# Altium schematic sheet CDU_Logic.SchDoc(CDU_Logic)
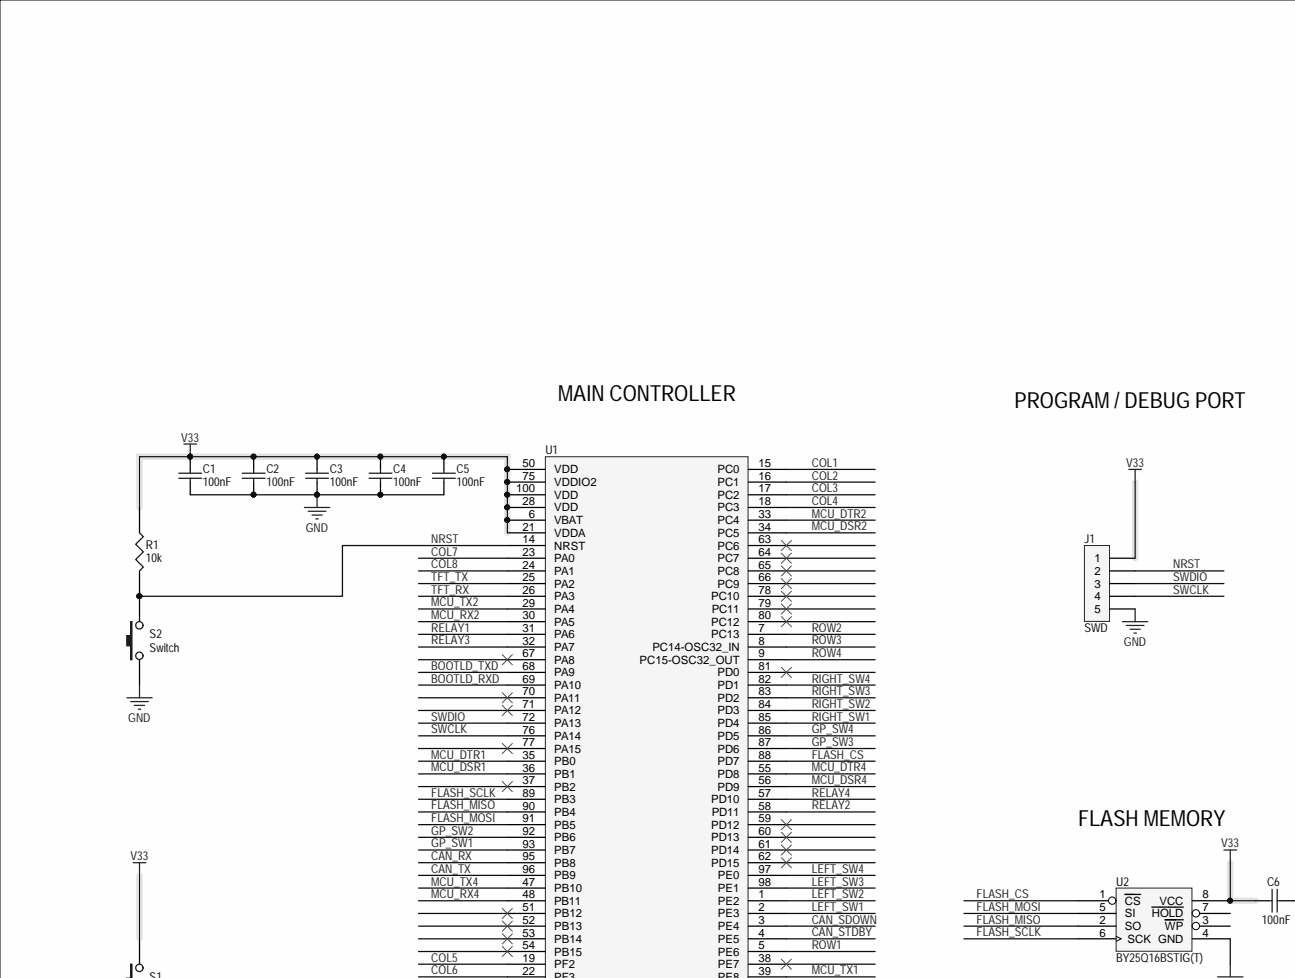
[diagram p0: CDU_Logic.SchDoc, top left]
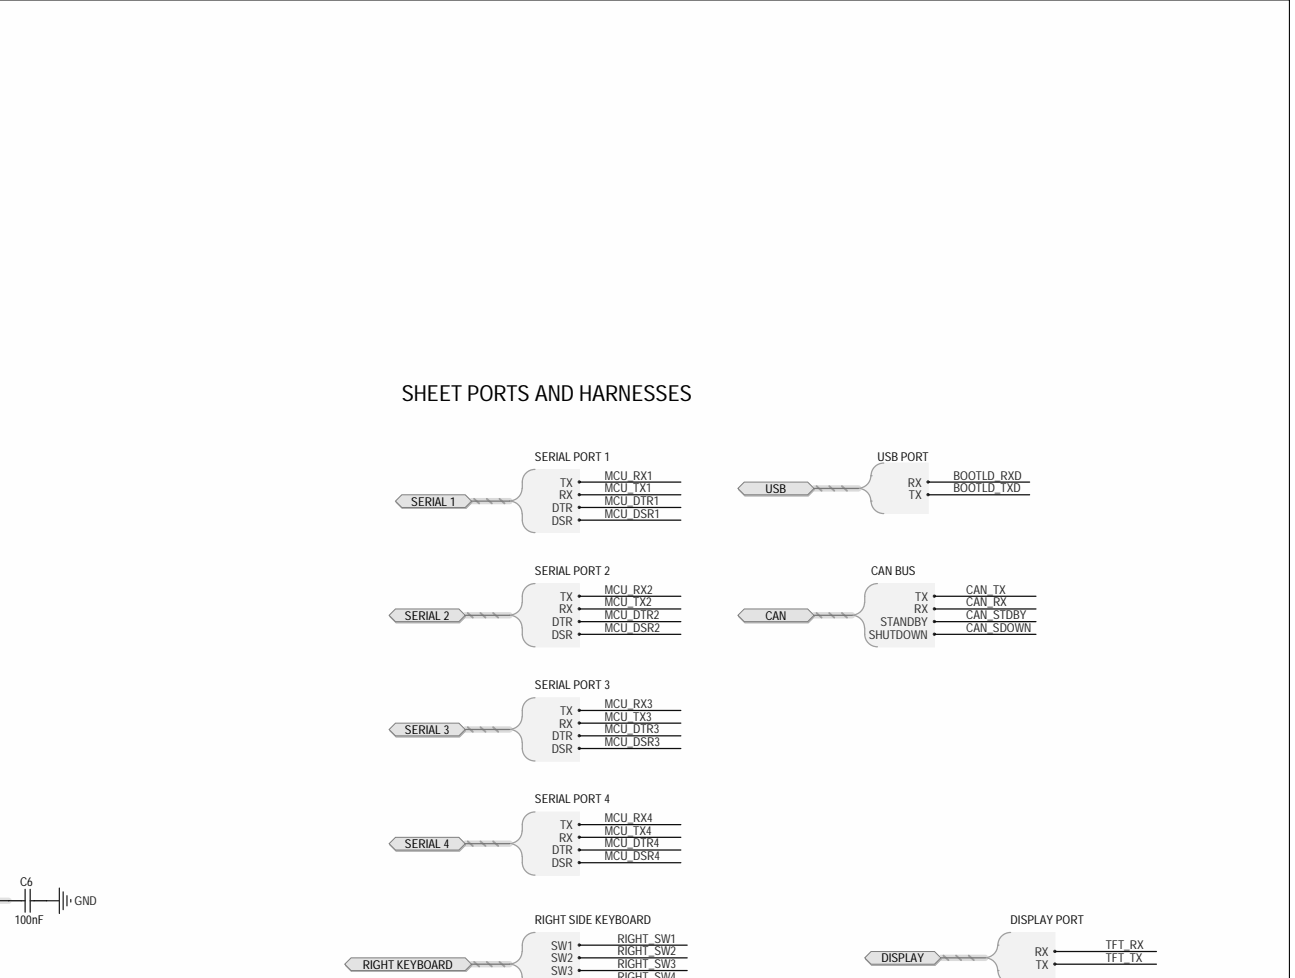
[diagram p1: CDU_Logic.SchDoc, top right]
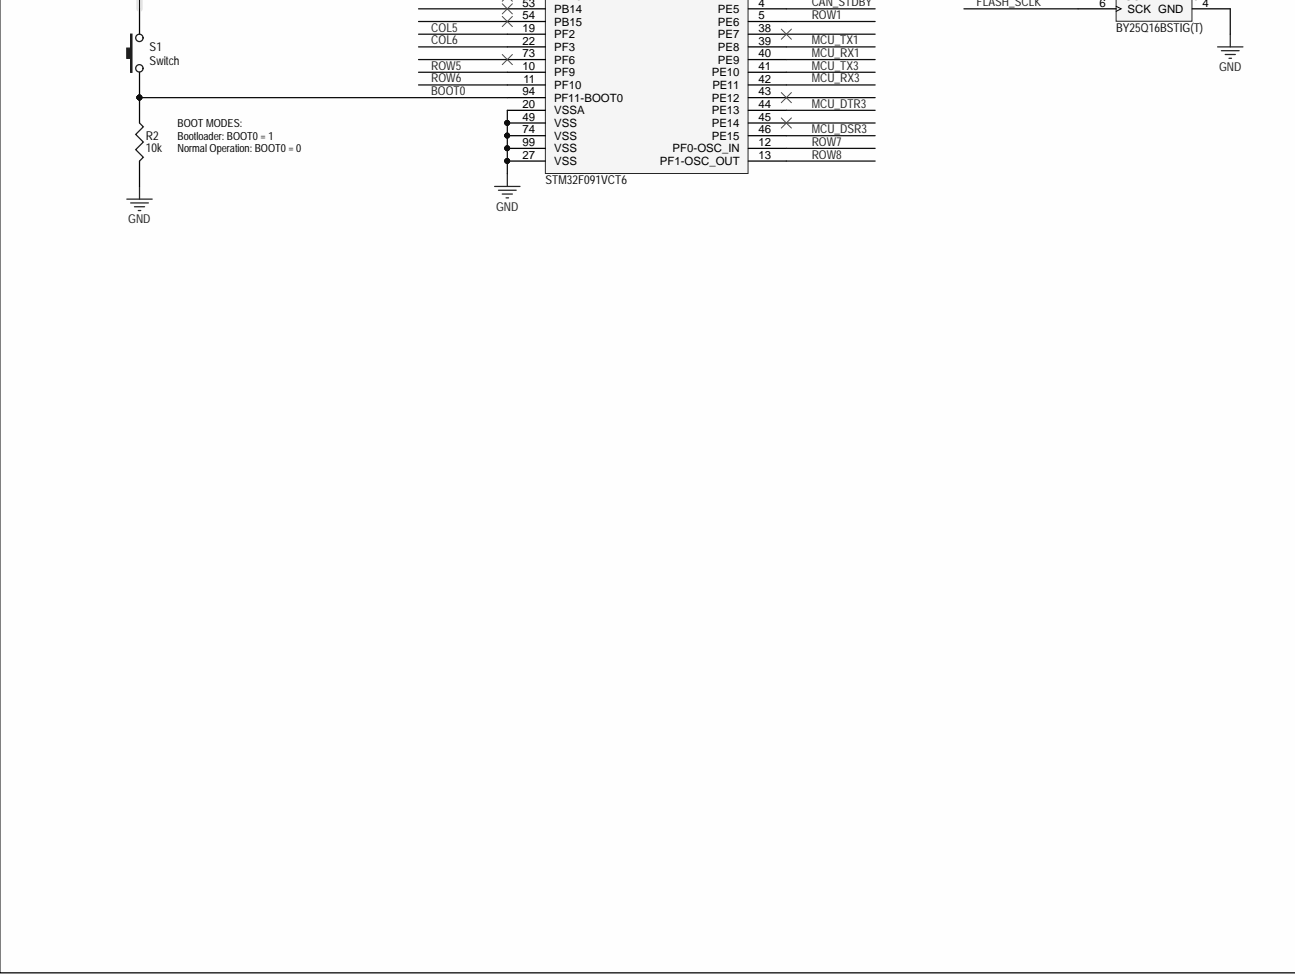
[diagram p2: CDU_Logic.SchDoc, bottom left]
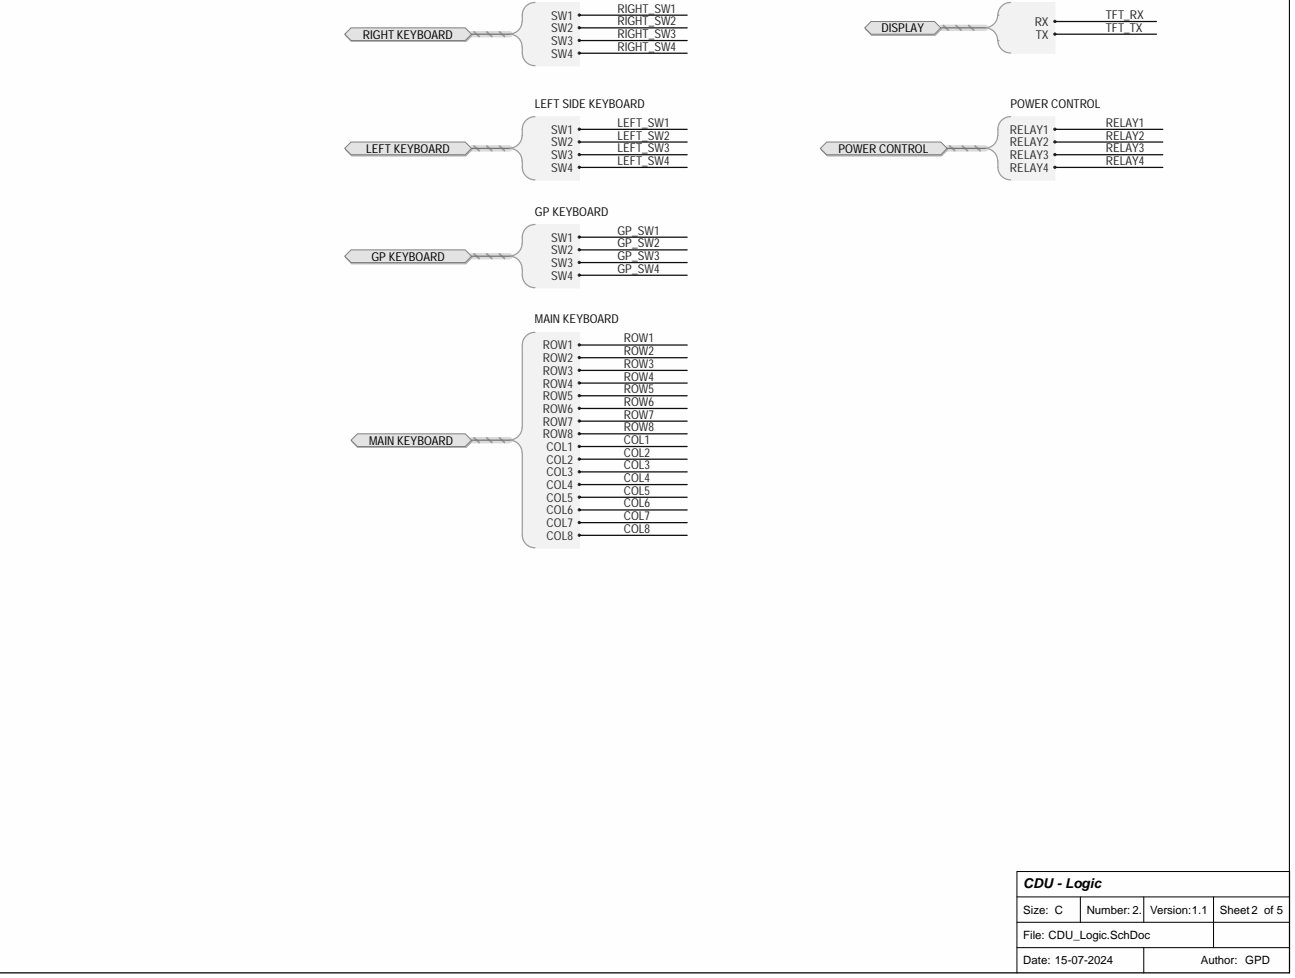
[diagram p3: CDU_Logic.SchDoc, bottom right]

## Parts
C1  100nF  [C0402]
C2  100nF  [C0402]
C3  100nF  [C0402]
C4  100nF  [C0402]
C5  100nF  [C0402]
C6  100nF  [C0402]
J1  SWD  [HDR1X5]
R1  10k  [R0402]
R2  10k  [R0402]
S1  Switch  [SWITCH_A06_RA]
S2  Switch  [SWITCH_A06_RA]
U1  STM32F091VCT6  [F-QFP14X14-G100/N]
U2  BY25Q16BSTIG(T)  [SO-G8]

## Ports
CAN, CAN.RX, CAN.SHUTDOWN, CAN.STANDBY, CAN.TX, DISPLAY, DISPLAY.RX, DISPLAY.TX, GP KEYBOARD, GP KEYBOARD.SW1, GP KEYBOARD.SW2, GP KEYBOARD.SW3, GP KEYBOARD.SW4, LEFT KEYBOARD, LEFT KEYBOARD.SW1, LEFT KEYBOARD.SW2, LEFT KEYBOARD.SW3, LEFT KEYBOARD.SW4, MAIN KEYBOARD, MAIN KEYBOARD.COL1, MAIN KEYBOARD.COL2, MAIN KEYBOARD.COL3, MAIN KEYBOARD.COL4, MAIN KEYBOARD.COL5, MAIN KEYBOARD.COL6, MAIN KEYBOARD.COL7, MAIN KEYBOARD.COL8, MAIN KEYBOARD.ROW1, MAIN KEYBOARD.ROW2, MAIN KEYBOARD.ROW3, MAIN KEYBOARD.ROW4, MAIN KEYBOARD.ROW5, MAIN KEYBOARD.ROW6, MAIN KEYBOARD.ROW7, MAIN KEYBOARD.ROW8, POWER CONTROL, POWER CONTROL.RELAY1, POWER CONTROL.RELAY2, POWER CONTROL.RELAY3, POWER CONTROL.RELAY4, RIGHT KEYBOARD, RIGHT KEYBOARD.SW1, RIGHT KEYBOARD.SW2, RIGHT KEYBOARD.SW3, RIGHT KEYBOARD.SW4, SERIAL 1, SERIAL 1.DSR, SERIAL 1.DTR, SERIAL 1.RX, SERIAL 1.TX, SERIAL 2, SERIAL 2.DSR, SERIAL 2.DTR, SERIAL 2.RX, SERIAL 2.TX, SERIAL 3, SERIAL 3.DSR, SERIAL 3.DTR, SERIAL 3.RX, SERIAL 3.TX, SERIAL 4, SERIAL 4.DSR, SERIAL 4.DTR, SERIAL 4.RX, SERIAL 4.TX, USB, USB.RX, USB.TX

## Nets
GND: C1.2 C2.2 C3.2 C4.2 C5.2 C6.1 J1.5 R2.2 S2.2 U1.20(VSSA) U1.27(VSS) U1.49(VSS) U1.74(VSS) U1.99(VSS) U2.4(GND)
V33: C1.1 C2.1 C3.1 C4.1 C5.1 C6.2 J1.1 R1.1 S1.1 U1.6(VBAT) U1.21(VDDA) U1.28(VDD) U1.50(VDD) U1.75(VDDIO2) U1.100(VDD) U2.8(VCC)
BOOT0: R2.1 S1.2 U1.94(PF11-BOOT0)
BOOTLD_RXD: U1.69(PA10) ; port USB
BOOTLD_TXD: U1.68(PA9) ; port USB
CAN_RX: U1.95(PB8) ; port CAN
CAN_SDOWN: U1.3(PE4) ; port CAN
CAN_STDBY: U1.4(PE5) ; port CAN
CAN_TX: U1.96(PB9) ; port CAN
COL1: U1.15(PC0) ; port MAIN KEYBOARD
COL2: U1.16(PC1) ; port MAIN KEYBOARD
COL3: U1.17(PC2) ; port MAIN KEYBOARD
COL4: U1.18(PC3) ; port MAIN KEYBOARD
COL5: U1.19(PF2) ; port MAIN KEYBOARD
COL6: U1.22(PF3) ; port MAIN KEYBOARD
COL7: U1.23(PA0) ; port MAIN KEYBOARD
COL8: U1.24(PA1) ; port MAIN KEYBOARD
FLASH_CS: U1.88(PD7) U2.1(CS)
FLASH_MISO: U1.90(PB4) U2.2(SO)
FLASH_MOSI: U1.91(PB5) U2.5(SI)
FLASH_SCLK: U1.89(PB3) U2.6(SCK)
GP_SW1: U1.93(PB7) ; port GP KEYBOARD
GP_SW2: U1.92(PB6) ; port GP KEYBOARD
GP_SW3: U1.87(PD6) ; port GP KEYBOARD
GP_SW4: U1.86(PD5) ; port GP KEYBOARD
LEFT_SW1: U1.2(PE3) ; port LEFT KEYBOARD
LEFT_SW2: U1.1(PE2) ; port LEFT KEYBOARD
LEFT_SW3: U1.98(PE1) ; port LEFT KEYBOARD
LEFT_SW4: U1.97(PE0) ; port LEFT KEYBOARD
MCU_DSR1: U1.36(PB1) ; port SERIAL 1
MCU_DSR2: U1.34(PC5) ; port SERIAL 2
MCU_DSR3: U1.46(PE15) ; port SERIAL 3
MCU_DSR4: U1.56(PD9) ; port SERIAL 4
MCU_DTR1: U1.35(PB0) ; port SERIAL 1
MCU_DTR2: U1.33(PC4) ; port SERIAL 2
MCU_DTR3: U1.44(PE13) ; port SERIAL 3
MCU_DTR4: U1.55(PD8) ; port SERIAL 4
MCU_RX1: U1.40(PE9) ; port SERIAL 1
MCU_RX2: U1.30(PA5) ; port SERIAL 2
MCU_RX3: U1.42(PE11) ; port SERIAL 3
MCU_RX4: U1.48(PB11) ; port SERIAL 4
MCU_TX1: U1.39(PE8) ; port SERIAL 1
MCU_TX2: U1.29(PA4) ; port SERIAL 2
MCU_TX3: U1.41(PE10) ; port SERIAL 3
MCU_TX4: U1.47(PB10) ; port SERIAL 4
NRST: J1.2 R1.2 S2.1 U1.14(NRST)
RELAY1: U1.31(PA6) ; port POWER CONTROL
RELAY2: U1.58(PD11) ; port POWER CONTROL
RELAY3: U1.32(PA7) ; port POWER CONTROL
RELAY4: U1.57(PD10) ; port POWER CONTROL
RIGHT_SW1: U1.85(PD4) ; port RIGHT KEYBOARD
RIGHT_SW2: U1.84(PD3) ; port RIGHT KEYBOARD
RIGHT_SW3: U1.83(PD2) ; port RIGHT KEYBOARD
RIGHT_SW4: U1.82(PD1) ; port RIGHT KEYBOARD
ROW1: U1.5(PE6) ; port MAIN KEYBOARD
ROW2: U1.7(PC13) ; port MAIN KEYBOARD
ROW3: U1.8(PC14-OSC32_IN) ; port MAIN KEYBOARD
ROW4: U1.9(PC15-OSC32_OUT) ; port MAIN KEYBOARD
ROW5: U1.10(PF9) ; port MAIN KEYBOARD
ROW6: U1.11(PF10) ; port MAIN KEYBOARD
ROW7: U1.12(PF0-OSC_IN) ; port MAIN KEYBOARD
ROW8: U1.13(PF1-OSC_OUT) ; port MAIN KEYBOARD
SWCLK: J1.4 U1.76(PA14)
SWDIO: J1.3 U1.72(PA13)
TFT_RX: U1.26(PA3) ; port DISPLAY
TFT_TX: U1.25(PA2) ; port DISPLAY

Not connected: U1 37(PB2) 38(PE7) 43(PE12) 45(PE14) 51(PB12) 52(PB13) 53(PB14) 54(PB15) 59(PD12) 60(PD13) 61(PD14) 62(PD15) 63(PC6) 64(PC7) 65(PC8) 66(PC9) 67(PA8) 70(PA11) 71(PA12) 73(PF6) 77(PA15) 78(PC10) 79(PC11) 80(PC12) 81(PD0); U2 3(WP) 7(HOLD)

## Library data of the parts
C1-C6 = CAP: 100nF ±10% 16V X7R 0402 Multilayer Ceramic Capacitors MLCC - SMD/SMT RoHS; Manufacturer Part Number: C0402C104K4RAC-TU; Manufacturer: KEMET; Value: 100nF; Supplier Part Number 3: 399-C0402C104K4RAC7867DKR-ND; Supplier Part Number 2: 8015145; Supplier Part Number 1: 80-C0402C104K4R; Supplier 3: Digikey; Supplier 2: Rs; Supplier 1: Mouser; Library Name: Miscellaneous Devices.SchLib; Component Kind: Standard
J1 = CON5: Free pads - do not populate; Value: SWD; Supplier Part Number 3: N/A; Supplier Part Number 2: N/A; Supplier Part Number 1: N/A; Supplier 3: N/A; Supplier 2: N/A; Supplier 1: N/A; Manufacturer Part Number: N/A; Manufacturer: N/A; Library Name: Miscellaneous Devices.SchLib; Component Kind: Standard
R1 R2 = RES1: Res Thin Film 0402 10K Ohm 0.1% 0.063W(1/16W) ±50ppm/C Pad SMD T/R; Manufacturer Part Number: RT0402BRE0710KL; Manufacturer: Yageo; Value: 10k; Supplier Part Number 3: YAG2305CT-ND; Supplier Part Number 2: YAG2305DKR-ND; Supplier Part Number 1: 603-RT0402BRE0710KL; Supplier 3: Digikey; Supplier 2: Digikey; Supplier 1: Mouser; Library Name: Miscellaneous Devices.SchLib; Component Kind: Standard
S1 S2 = SW-PB: Switch Tactile N.O. SPST Round Button PC Pins 0.05A 12VDC 1.57N Thru-Hole Automotive Bulk; Manufacturer Part Number: SKHHLRA010; Manufacturer: Alps Electric; Value: Switch; Supplier Part Number 3: C383800; Supplier Part Number 2: 688-SKHHLR; Supplier Part Number 1: 4809-SKHHLRA010-ND; Supplier 3: LCSC; Supplier 2: Mouser; Supplier 1: Digikey; Library Name: Miscellaneous Devices.SchLib; Component Kind: Standard
U1 = STM32F091VCT6: MCU 32-Bit STM32F ARM Cortex M0 RISC 256KB Flash 2.5V/3.3V 100-Pin LQFP Tray; Manufacturer: STMicroelectronics; Value: STM32F091VCT6; Supplier Part Number 3: 497-15168-ND; Supplier Part Number 2: STM32F091VCT6; Supplier Part Number 1: 511-STM32F091VCT6; Supplier 3: Digikey; Supplier 2: TME; Supplier 1: Mouser; Manufacturer Part Number: STM32F091VCT6; Library Name: STM32.SchLib; ComponentLink1URL: https://www.st.com/resource/en/datasheet/stm32f091vc.pdf; ComponentLink1Description: Datasheet; Component Kind: Standard
U2 = AT25F2048: SOP-8 NOR FLASH ROHS; Manufacturer: BOYAMICRO; Value: BY25Q16BSTIG(T); Supplier Part Number 3: C382744; Supplier Part Number 2: C382744; Supplier Part Number 1: C382744; Supplier 3: LCSC; Supplier 2: LCSC; Supplier 1: LCSC; Manufacturer Part Number: BY25Q16BSTIG(T); Library Name: ICs.SchLib; ComponentLink1URL: https://datasheet.lcsc.com/lcsc/1912111437_BOYAMICRO-BY25Q16BSTIG-T_C382744.pdf?_gl=1*72e4c3*_gcl_au*MTUzNDU1MTE0Mi4xNzE0NDQxNzc1*_ga*NDgwNzM5Njg2LjE3MTQ0NDE3Nzc.*_ga_98M84MKSZH*MTcxNTI5MzI1My4xNS4xLjE3MTUyOTMyNTQuNTkuMC4w; ComponentLink1Description: Datasheet; Component Kind: Standard
